# Altium schematic sheet Input_and_Output.SchDoc
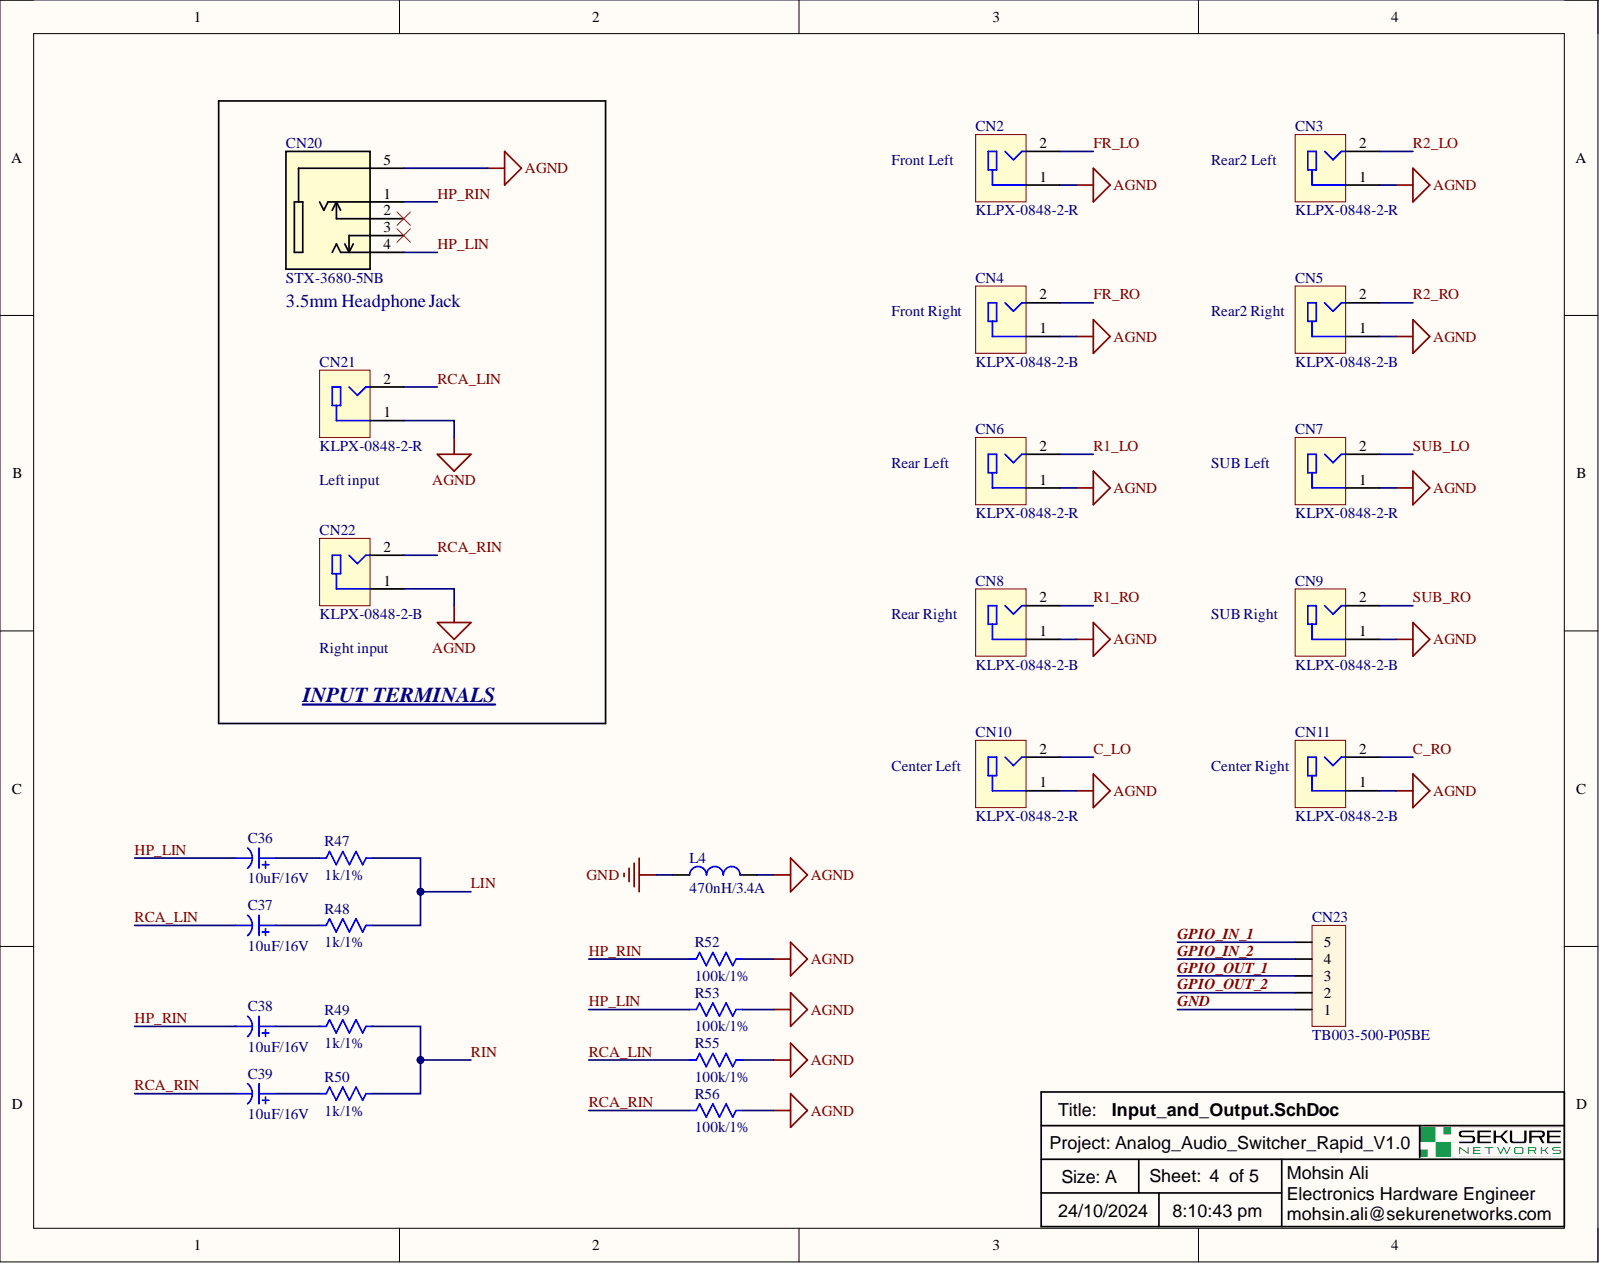
[diagram p0: Input_and_Output.SchDoc]

## Parts
C36  value 10uF/16V  [CAPAE4643X55M]  (hidden comment: Capacitor)
C37  value 10uF/16V  [CAPAE4643X55M]  (hidden comment: Capacitor)
C38  value 10uF/16V  [CAPAE4643X55M]  (hidden comment: Capacitor)
C39  value 10uF/16V  [CAPAE4643X55M]  (hidden comment: Capacitor)
CN2  value KLPX-0848-2-R  [KLPX-0848-2-R]  (hidden comment: Connector)
CN3  value KLPX-0848-2-R  [KLPX-0848-2-R]  (hidden comment: Connector)
CN4  value KLPX-0848-2-B  [KLPX-0848-2-B]  (hidden comment: Connector)
CN5  value KLPX-0848-2-B  [KLPX-0848-2-B]  (hidden comment: Connector)
CN6  value KLPX-0848-2-R  [KLPX-0848-2-R]  (hidden comment: Connector)
CN7  value KLPX-0848-2-R  [KLPX-0848-2-R]  (hidden comment: Connector)
CN8  value KLPX-0848-2-B  [KLPX-0848-2-B]  (hidden comment: Connector)
CN9  value KLPX-0848-2-B  [KLPX-0848-2-B]  (hidden comment: Connector)
CN10  value KLPX-0848-2-R  [KLPX-0848-2-R]  (hidden comment: Connector)
CN11  value KLPX-0848-2-B  [KLPX-0848-2-B]  (hidden comment: Connector)
CN20  value STX-3680-5NB  [STX-3680_KYC]  (hidden comment: Connector)
CN21  value KLPX-0848-2-R  [KLPX-0848-2-R]  (hidden comment: Connector)
CN22  value KLPX-0848-2-B  [KLPX-0848-2-B]  (hidden comment: Connector)
CN23  value TB003-500-P05BE  [TB003-500-P05BE]  (hidden comment: Connector)
L4  value 470nH/3.4A  [IND 0806]  (hidden comment: Inductor)
R47  value 1k/1%  [RES 0603]  (hidden comment: Resistor)
R48  value 1k/1%  [RES 0603]  (hidden comment: Resistor)
R49  value 1k/1%  [RES 0603]  (hidden comment: Resistor)
R50  value 1k/1%  [RES 0603]  (hidden comment: Resistor)
R52  value 100k/1%  [RES 0603]  (hidden comment: Resistor)
R53  value 100k/1%  [RES 0603]  (hidden comment: Resistor)
R55  value 100k/1%  [RES 0603]  (hidden comment: Resistor)
R56  value 100k/1%  [RES 0603]  (hidden comment: Resistor)

## Nets
AGND: CN2.1 CN3.1 CN4.1 CN5.1 CN6.1 CN7.1 CN8.1 CN9.1 CN10.1 CN11.1 CN20.5 CN21.1 CN22.1 L4.2 R52.2 R53.2 R55.2 R56.2
C_LO: CN10.2
C_RO: CN11.2
FR_LO: CN2.2
FR_RO: CN4.2
GND: CN23.1 L4.1
GPIO_IN_1: CN23.5
GPIO_IN_2: CN23.4
GPIO_OUT_1: CN23.3
GPIO_OUT_2: CN23.2
HP_LIN: C36.2 CN20.4 R53.1
HP_RIN: C38.2 CN20.1 R52.1
LIN: R47.1 R48.1
R1_LO: CN6.2
R1_RO: CN8.2
R2_LO: CN3.2
R2_RO: CN5.2
RCA_LIN: C37.2 CN21.2 R55.1
RCA_RIN: C39.2 CN22.2 R56.1
RIN: R49.1 R50.1
SUB_LO: CN7.2
SUB_RO: CN9.2
NetC36_1: C36.1 R47.2
NetC37_1: C37.1 R48.2
NetC38_1: C38.1 R49.2
NetC39_1: C39.1 R50.2

Not connected: CN20 2 3

## Library data of the parts
C36-C39 = 865080340001: Cap, 10ΜF, 16V, SMD; Manufacturer: Wurth Electronics; Capacitance: 10uF; Impedance: 2.2R; Life (Hours): 2000Hour; Polarity: Polar; Ripple Current: 80mA; Tolerance: 20%; Case/Package: Radial; Category: Aluminum Electrolytic Capacitors; Component Kind: Standard; ComponentLink1Description: http://www.farnell.com/datasheets/1880118.pdf?src-supplier=Farnell; ComponentLink1URL: http://www.farnell.com/datasheets/1880118.pdf?src-supplier=Farnell; ComponentLink2Description: http://www.farnell.com/datasheets/1880118.pdf?src-supplier=Element14; ComponentLink2URL: http://www.farnell.com/datasheets/1880118.pdf?src-supplier=Element14; ComponentLink3Description: http://www.farnell.com/datasheets/1880118.pdf?src-supplier=Newark; ComponentLink3URL: http://www.farnell.com/datasheets/1880118.pdf?src-supplier=Newark; ComponentLink4Description: https://katalog.we-online.de/pbs/datasheet/865080340001.pdf?src-supplier=Digi-Key; ComponentLink4URL: https://katalog.we-online.de/pbs/datasheet/865080340001.pdf?src-supplier=Digi-Key; Diameter: 4mm; DigiKey: 732-8411-1-ND; Height: 5.5mm; Height - Seated (Max): 0.217inch; Lead Free: Lead Free; Library Name: Radial Capacitors.IntLib; Max Operating Temperature: 105°C; Min Operating Temperature: -55°C; Mount: Surface Mount; P/N: 865080340001; Package Quantity: 2000; Packaging: Cut Tape; Pricing 1: 1=0.18000, 50=0.15100, 100=0.13100, 250=0.11700, 500=0.10200, 1000=0.09700 (USD); REACH SVHC: Unknown; Ripple Current (AC): 80mA; RoHS: Yes; RoHS Compliant: Yes; Stock 1: 11596; Supplier 1: Digi-Key; Voltage Rating: 16V; Voltage Rating (DC): 16V
CN2 CN3 CN6 CN7 CN10 CN21 = KLPX-0848-2-R: RCA Phono Connectors PCB VERTICAL JACK RED; Manufacturer: Kycon; Category: Connectors; Component Kind: Standard; ComponentLink1Description: http://www.mouser.com/ds/2/222/KLPX-334830.pdf?src-supplier=Mouser; ComponentLink1URL: http://www.mouser.com/ds/2/222/KLPX-334830.pdf?src-supplier=Mouser; ComponentLink2Description: http://domino2.kycon.com/catalog_PDF/Standard%20Catalog%20page/RCA%20Phono%20Jacks/SKLPX.pdf?src-supplier=Avnet; ComponentLink2URL: http://domino2.kycon.com/catalog_PDF/Standard%20Catalog%20page/RCA%20Phono%20Jacks/SKLPX.pdf?src-supplier=Avnet; ComponentLink3Description: http://www.kycon.com/2013Catalogpage/RCA/KLPX.pdf?src-supplier=Digikey; ComponentLink3URL: http://www.kycon.com/2013Catalogpage/RCA/KLPX.pdf?src-supplier=Digikey; ComponentLink4Description: https://datasheet.octopart.com/KLPX-0848-2-R-Kycon-datasheet-116534117.pdf?src-supplier=Toby+Electronics; ComponentLink4URL: https://datasheet.octopart.com/KLPX-0848-2-R-Kycon-datasheet-116534117.pdf?src-supplier=Toby+Electronics; DigiKey: 2092-KLPX-0848-2-R-ND; Library Name: Connectors.SchLib; P/N: KLPX-0848-2-R; Pricing: 1=1.16, 10=0.885, 25=0.7956, 50=0.7348, 100=0.6795, 250=0.61388, 500=0.5695, 1000=0.52924, 2500=0.48174 (USD); RoHS: Yes; Stock: 615; Supplier: Digikey
CN4 CN5 CN8 CN9 CN11 CN22 = KLPX-0848-2-B: RCA Phono Connectors PCB VERTICAL JACK BLACK; Manufacturer: Kycon; Category: Connectors; Component Kind: Standard; ComponentLink1Description: http://www.mouser.com/ds/2/222/KLPX-334830.pdf?src-supplier=Mouser; ComponentLink1URL: http://www.mouser.com/ds/2/222/KLPX-334830.pdf?src-supplier=Mouser; ComponentLink2Description: http://domino2.kycon.com/catalog_PDF/Standard%20Catalog%20page/RCA%20Phono%20Jacks/SKLPX.pdf?src-supplier=Avnet; ComponentLink2URL: http://domino2.kycon.com/catalog_PDF/Standard%20Catalog%20page/RCA%20Phono%20Jacks/SKLPX.pdf?src-supplier=Avnet; ComponentLink3Description: http://www.kycon.com/2013Catalogpage/RCA/KLPX.pdf?src-supplier=Digikey; ComponentLink3URL: http://www.kycon.com/2013Catalogpage/RCA/KLPX.pdf?src-supplier=Digikey; ComponentLink4Description: https://datasheet.octopart.com/KLPX-0848-2-B-Kycon-datasheet-116534117.pdf?src-supplier=Toby+Electronics; ComponentLink4URL: https://datasheet.octopart.com/KLPX-0848-2-B-Kycon-datasheet-116534117.pdf?src-supplier=Toby+Electronics; DigiKey: 2092-KLPX-0848-2-B-ND; Library Name: Connectors.IntLib; P/N: KLPX-0848-2-B; Pricing: 1=0.98, 10=0.826, 25=0.7928, 50=0.7758, 100=0.7421, 250=0.67468, 500=0.60722, 1000=0.53974, 2500=0.48914 (USD); RoHS: Yes; Stock: 3475; Supplier: Digikey
CN20 = STX-3680-5NB: Conn Audio F 5 POS Solder ST Thru-Hole 5 Terminal 1 Port; Manufacturer: Kycon; Body Color: Black; Gender: Female; Number of Contacts: 5; Shielding: Unshielded; Voltage Rating: 16V; Category: Connectors; Color: Black; Component Kind: Standard; ComponentLink1Description: http://www.mouser.com/ds/2/222/STX-3680-334630.pdf?src-supplier=Mouser; ComponentLink1URL: http://www.mouser.com/ds/2/222/STX-3680-334630.pdf?src-supplier=Mouser; ComponentLink2Description: http://www.kycon.com/Pub_Eng_Draw/STX-3680-5NB.pdf?src-supplier=Digikey; ComponentLink2URL: http://www.kycon.com/Pub_Eng_Draw/STX-3680-5NB.pdf?src-supplier=Digikey; ComponentLink3Description: https://datasheet.octopart.com/STX-3680-5NB-Kycon-datasheet-137322849.pdf?src-supplier=Sager+Electronics; ComponentLink3URL: https://datasheet.octopart.com/STX-3680-5NB-Kycon-datasheet-137322849.pdf?src-supplier=Sager+Electronics; Contact Material: Copper Alloy; Contact Plating: Silver; DigiKey: 2092-STX-3680-5NB-ND; Housing Material: Thermoplastic; Library Name: Connectors.IntLib; Max Operating Temperature: 85°C; Min Operating Temperature: -25°C; Mounting Technology: Through Hole; Orientation: Vertical; P/N: STX-3680-5NB; Package Quantity: 180; Packaging: Tray; Pricing: 1=1.81, 10=1.576, 25=1.5088, 40=1.4755, 190=1.40837, 380=1.27421, 570=1.17361, 950=1.00596, 2470=0.93889 (USD); Radiation Hardening: No; RoHS: Yes; RoHS Compliant: Yes; Series: STX-3680; Stock: 2747; Supplier: Digikey; Termination: Solder
CN23 = TB003-500-P05BE: Barrier Strip Terminal Block; Manufacturer: CUI Devices; Category: Terminal Blocks; Component Kind: Standard; DigiKey: 102-6164-ND; Library Name: Connectors.IntLib; P/N: TB003-500-P05BE; Pricing: 1=1.16, 10=0.612, 25=0.5028, 50=0.444, 100=0.3997, 250=0.3576, 500=0.33486, 1200=0.31403, 6000=0.29081 (USD); RoHS: Yes; Stock: 902; Supplier: Digikey
L4 = 1286AS-H-R47M=P2: Inductor Power Shielded Wirewound 0.47uH 20% 1MHz Metal 3.2A 0.052Ohm DCR 0806 T/R; Manufacturer: Murata; Core Material: Ferrite; Current Rating: 3.4A; DC Resistance (DCR): 52mR; Max DC Current: 3.8A; Case Code (Imperial): 0806; Case Code (Metric): 2016; Case/Package: 0806; Category: Fixed Inductors; Component Kind: Standard; ComponentLink1Description: http://www.farnell.com/datasheets/2021974.pdf?src-supplier=Farnell; ComponentLink1URL: http://www.farnell.com/datasheets/2021974.pdf?src-supplier=Farnell; ComponentLink2Description: http://www.toko.co.jp/products/pdf/inductors/dfe201612c.pdf?src-supplier=Avnet; ComponentLink2URL: http://www.toko.co.jp/products/pdf/inductors/dfe201612c.pdf?src-supplier=Avnet; ComponentLink3Description: http://search.murata.co.jp/Ceramy/image/img/P02/J(E)TE243A-0010_DFE201612C_reference.pdf?src-supplier=Digikey; ComponentLink3URL: http://search.murata.co.jp/Ceramy/image/img/P02/J(E)TE243A-0010_DFE201612C_reference.pdf?src-supplier=Digikey; ComponentLink4Description: http://www.toko.co.jp/products/pdf/inductors/dfe201612c.pdf?src-supplier=Digikey; ComponentLink4URL: http://www.toko.co.jp/products/pdf/inductors/dfe201612c.pdf?src-supplier=Digikey; Depth: 1.6mm; DigiKey: 490-10606-1-ND; Height - Seated (Max): 0.047inch; Length: 0.079inch; Library Name: Inductors_NEW.IntLib; Max Operating Temperature: 85°C; Min Operating Temperature: -40°C; Mounting Technology: Surface Mount; P/N: 1286AS-H-R47M=P2; Packaging: Cut Tape; Pricing: 1=0.34, 10=0.264, 25=0.2388, 50=0.2214, 100=0.2051, 250=0.18544, 500=0.17182, 1000=0.15914 (USD); RoHS: Yes; RoHS Compliant: Yes; Series: DFE201612C; Shielding: Shielded; Stock: 11624; Supplier: Digikey; Test Frequency: 1MHz; Tolerance: 20%; Width: 0.063inch
R47-R50 = CRCW06031K00FKEA: RES SMD 1 kohm 1% Thick Film, 100 mW; Manufacturer: Vishay Dale; Max Power Dissipation: 100mW; Resistance: 1kR; Temperature Coefficient: 100ppm/°C; Voltage Rating: 75V; Case Code (Imperial): 0603; Case Code (Metric): 1608; Case/Package: 0603; Component Kind: Standard; ComponentLink1Description: https://datasheet.ciiva.com/11808/0900766b80d98afc-11808625.pdf?src-supplier=RSComponents; ComponentLink1URL: https://datasheet.ciiva.com/11808/0900766b80d98afc-11808625.pdf?src-supplier=RSComponents; ComponentLink2Description: https://datasheet.ciiva.com/2465/dcrcwe3-2465536.pdf?src-supplier=Digi-Key; ComponentLink2URL: https://datasheet.ciiva.com/2465/dcrcwe3-2465536.pdf?src-supplier=Digi-Key; ComponentLink3Description: https://datasheet.ciiva.com/8336/dcrcwe3-8336822.pdf?src-supplier=Avnet; ComponentLink3URL: https://datasheet.ciiva.com/8336/dcrcwe3-8336822.pdf?src-supplier=Avnet; ComponentLink4Description: https://datasheet.ciiva.com/16515/dcrcwe3-16515078.pdf?src-supplier=Avnet+Asia; ComponentLink4URL: https://datasheet.ciiva.com/16515/dcrcwe3-16515078.pdf?src-supplier=Avnet+Asia; ComponentLink5Description: https://datasheet.ciiva.com/46441/1720486-46441622.pdf?src-supplier=Element14; ComponentLink5URL: https://datasheet.ciiva.com/46441/1720486-46441622.pdf?src-supplier=Element14; ComponentLink6Description: https://datasheet.ciiva.com/26316/fld-crcw-e3-data-en-26316551.pdf?src-supplier=Distrelec; ComponentLink6URL: https://datasheet.ciiva.com/26316/fld-crcw-e3-data-en-26316551.pdf?src-supplier=Distrelec; ComponentLink7Description: https://datasheet.ciiva.com/46441/1720486-46441623.pdf?src-supplier=Element14; ComponentLink7URL: https://datasheet.ciiva.com/46441/1720486-46441623.pdf?src-supplier=Element14; ComponentLink8Description: https://datasheet.ciiva.com/46385/1697337-46385497.pdf?src-supplier=Element14; ComponentLink8URL: https://datasheet.ciiva.com/46385/1697337-46385497.pdf?src-supplier=Element14; ComponentLink9Description: https://datasheet.ciiva.com/3257/dcrcwe3-3257132.pdf?src-supplier=Avnet; ComponentLink9URL: https://datasheet.ciiva.com/3257/dcrcwe3-3257132.pdf?src-supplier=Avnet; ComponentLink10Description: https://datasheet.ciiva.com/16199/dcrcwe3-16199053.pdf?src-supplier=Future+Electronics; ComponentLink10URL: https://datasheet.ciiva.com/16199/dcrcwe3-16199053.pdf?src-supplier=Future+Electronics; ComponentLink11Description: https://datasheet.ciiva.com/2465/dcrcwe3-2465534.pdf?src-supplier=Digi-Key; ComponentLink11URL: https://datasheet.ciiva.com/2465/dcrcwe3-2465534.pdf?src-supplier=Digi-Key; ComponentLink12Description: https://datasheet.ciiva.com/16893/dcrcwe3-16893742.pdf?src-supplier=Avnet+Europe; ComponentLink12URL: https://datasheet.ciiva.com/16893/dcrcwe3-16893742.pdf?src-supplier=Avnet+Europe; ComponentLink13Description: https://datasheet.ciiva.com/2465/dcrcwe3-2465535.pdf?src-supplier=Digi-Key; ComponentLink13URL: https://datasheet.ciiva.com/2465/dcrcwe3-2465535.pdf?src-supplier=Digi-Key; Composition: Thick Film; Contact Plating: Tin; Depth: 0.85mm; DigiKey: 541-1.00KHCT-ND; Features: Automotive AEC-Q200; Height: 0.45mm; Lead Free: Lead Free; Length: 1.55mm; Library Name: Resistors.IntLib; Max Operating Temperature: 155°C; Military Standard: Not; Min Operating Temperature: -55°C; Mount: Surface Mount; Number of Pins: 2; Number of Terminations: 2; P/N: CRCW06031K00FKEA; Package Quantity: 10; Packaging: Tape and Reel; Power Rating: 100mW; Pricing 1: 1=0.10000, 10=0.03400, 100=0.01390, 1000=0.00623, 2500=0.00540 (USD); Radiation Hardening: No; REACH SVHC: Unknown; RoHS: Yes; Stock 1: 1070064; Supplier 1: Digi-Key; Termination: SMD/SMT; Tolerance: 1%; Voltage Rating (DC): 75V; Weight: 7.1E-05oz; Width: 0.033inch
R52 R53 R55 R56 = RC0603FR-07100KL: RES SMD 100K Ohm 1% 0603; Manufacturer: Yageo; Power Rating: 100mW; Resistance: 100kR; Temperature Coefficient: 100ppm/°C; Voltage Rating: 50V; Working Voltage: 75V; Case Code (Imperial): 0603; Case Code (Metric): 1608; Case/Package: 0603; Component Kind: Standard; ComponentLink1Description: https://datasheet.ciiva.com/11808/0900766b80f96399-11808107.pdf?src-supplier=RSComponents; ComponentLink1URL: https://datasheet.ciiva.com/11808/0900766b80f96399-11808107.pdf?src-supplier=RSComponents; ComponentLink2Description: https://datasheet.ciiva.com/46440/1868787-46440889.pdf?src-supplier=Element14; ComponentLink2URL: https://datasheet.ciiva.com/46440/1868787-46440889.pdf?src-supplier=Element14; ComponentLink3Description: https://datasheet.ciiva.com/7746/1738695-7746235.pdf?src-supplier=Element14; ComponentLink3URL: https://datasheet.ciiva.com/7746/1738695-7746235.pdf?src-supplier=Element14; ComponentLink4Description: https://datasheet.ciiva.com/7983/443971-7983593.pdf?src-supplier=Element14; ComponentLink4URL: https://datasheet.ciiva.com/7983/443971-7983593.pdf?src-supplier=Element14; ComponentLink5Description: https://datasheet.ciiva.com/7746/1738695-7746234.pdf?src-supplier=Element14; ComponentLink5URL: https://datasheet.ciiva.com/7746/1738695-7746234.pdf?src-supplier=Element14; ComponentLink6Description: https://datasheet.ciiva.com/22747/443971-22747516.pdf?src-supplier=Element14; ComponentLink6URL: https://datasheet.ciiva.com/22747/443971-22747516.pdf?src-supplier=Element14; Composition: Thick Film; Depth: 0.8mm; DigiKey: 311-100KHRCT-ND; Height: 0.45mm; Lead Free: Lead Free; Length: 1.6mm; Library Name: Resistors.IntLib; Max Operating Temperature: 155°C; Military Standard: Not; Min Operating Temperature: -55°C; Number of Pins: 2; Number of Terminations: 2; P/N: RC0603FR-07100KL; Packaging: Tape and Reel; Pricing 1: 1=0.10000, 10=0.02300, 100=0.00940, 1000=0.00421, 2500=0.00366 (USD); REACH SVHC: No SVHC; RoHS: Yes; Stock 1: 34090397; Supplier 1: Digi-Key; Termination: SMD/SMT; Tolerance: 1%; Voltage Rating (DC): 50V; Width: 0.8mm
